# Altium schematic sheet Sheet2.SchDoc
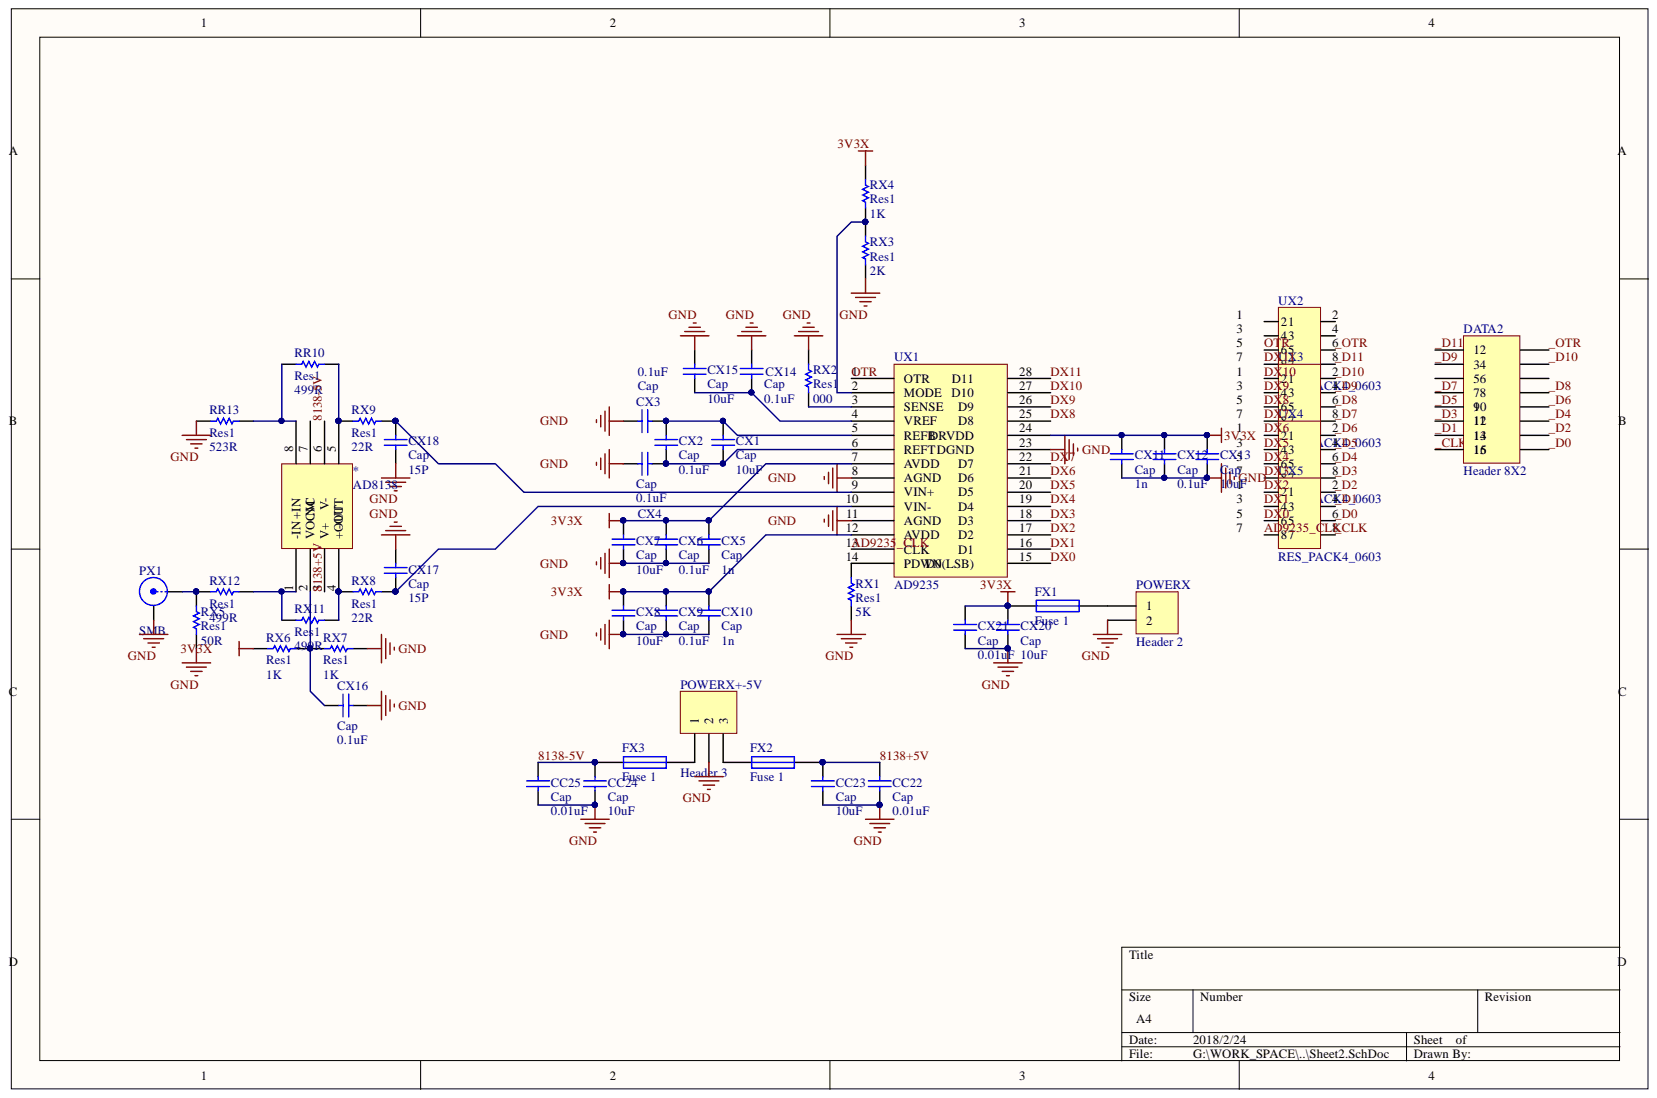
[diagram p0: Sheet2.SchDoc]

## Parts
*  AD8138  [AD8138]
CC22  Cap  value 0.01uF  [6-0805_N]
CC23  Cap  value 10uF  [C1206]
CC24  Cap  value 10uF  [C1206]
CC25  Cap  value 0.01uF  [6-0805_N]
CX1  Cap  value 10uF  [C1206]
CX2  Cap  value 0.1uF  [6-0805_N]
CX3  Cap  value 0.1uF  [6-0805_N]
CX4  Cap  value 0.1uF  [6-0805_N]
CX5  Cap  value 1n  [6-0805_N]
CX6  Cap  value 0.1uF  [6-0805_N]
CX7  Cap  value 10uF  [C1206]
CX8  Cap  value 10uF  [C1206]
CX9  Cap  value 0.1uF  [6-0805_N]
CX10  Cap  value 1n  [6-0805_N]
CX11  Cap  value 1n  [6-0805_N]
CX12  Cap  value 0.1uF  [6-0805_N]
CX13  Cap  value 10uF  [C1206]
CX14  Cap  value 0.1uF  [6-0805_N]
CX15  Cap  value 10uF  [C1206]
CX16  Cap  value 0.1uF  [6-0805_N]
CX17  Cap  value 15P  [6-0805_N]
CX18  Cap  value 15P  [6-0805_N]
CX20  Cap  value 10uF  [C1206]
CX21  Cap  value 0.01uF  [6-0805_N]
DATA2  Header 8X2  [HDR2X8]
FX1  Fuse 1  [6-0805_N]
FX2  Fuse 1  [6-0805_N]
FX3  Fuse 1  [6-0805_N]
POWERX  Header 2  [HDR1X2]
POWERX+-5V  Header 3  [HDR1X3]
PX1  SMB  [SMB_V-RJ45]
RR10  Res1  value 499R  [6-0805_N]
RR13  Res1  value 523R  [6-0805_N]
RX1  Res1  value 5K  [6-0805_N]
RX2  Res1  value 000  [6-0805_N]
RX3  Res1  value 2K  [6-0805_N]
RX4  Res1  value 1K  [6-0805_N]
RX5  Res1  value 50R  [6-0805_N]
RX6  Res1  value 1K  [6-0805_N]
RX7  Res1  value 1K  [6-0805_N]
RX8  Res1  value 22R  [6-0805_N]
RX9  Res1  value 22R  [6-0805_N]
RX11  Res1  value 499R  [6-0805_N]
RX12  Res1  value 499R  [6-0805_N]
UX1  AD9235  [AD9235]
UX2  RES_PACK4_0603  [8P4R_0603]
UX3  RES_PACK4_0603  [8P4R_0603]
UX4  RES_PACK4_0603  [8P4R_0603]
UX5  RES_PACK4_0603  [8P4R_0603]

## Nets
3V3X: CX5.2 CX6.2 CX7.2 CX8.2 CX9.2 CX10.2 CX11.1 CX12.1 CX13.1 CX20.2 CX21.2 FX1.1 RX4.2 RX6.2 UX1.7(AVDD) UX1.12(AVDD) UX1.24
GND: CC22.1 CC23.1 CC24.1 CC25.1 CX3.2 CX4.1 CX5.1 CX6.1 CX7.1 CX8.1 CX9.1 CX10.1 CX11.2 CX12.2 CX13.2 CX14.2 CX15.2 CX16.1 CX17.1 CX18.1 CX20.1 CX21.1 POWERX.2 POWERX+-5V.2 PX1.2 RR13.2 RX1.1 RX2.2 RX3.1 RX5.1 RX7.1 UX1.8(AGND) UX1.11(AGND) UX1.23
8138+5V: *.3(V+) CC22.2 CC23.2 FX2.2
8138-5V: *.6 CC24.2 CC25.2 FX3.1
_CLK: DATA2.15 UX5.8
_D0: DATA2.16 UX5.6
_D1: DATA2.13 UX5.4
_D2: DATA2.14 UX5.2
_D3: DATA2.11 UX4.8
_D4: DATA2.12 UX4.6
_D5: DATA2.9 UX4.4
_D6: DATA2.10 UX4.2
_D7: DATA2.7 UX3.8
_D8: DATA2.8 UX3.6
_D9: DATA2.3 UX3.4
_D10: DATA2.4 UX3.2
_D11: DATA2.1 UX2.8
_OTR: DATA2.2 UX2.6
AD9235_CLK: UX1.13 UX5.7(8_7)
DX0: UX1.15 UX5.5(6_5)
DX1: UX1.16 UX5.3(4_3)
DX2: UX1.17 UX5.1(2_1)
DX3: UX1.18 UX4.7(8_7)
DX4: UX1.19 UX4.5(6_5)
DX5: UX1.20 UX4.3(4_3)
DX6: UX1.21 UX4.1(2_1)
DX7: UX1.22 UX3.7(8_7)
DX8: UX1.25 UX3.5(6_5)
DX9: UX1.26 UX3.3(4_3)
DX10: UX1.27 UX3.1(2_1)
DX11: UX1.28 UX2.7(8_7)
OTR: UX1.1(OTR) UX2.5(6_5)
Net*_1: *.1(-IN_+IN) RX11.2 RX12.1
Net*_2: *.2(VOCM) CX16.2 RX6.1 RX7.2
Net*_4: *.4(+OUT) RX8.2 RX11.1
Net*_5: *.5 RR10.1 RX9.2
Net*_8: *.8 RR10.2 RR13.1
NetCX1_1: CX1.1 CX2.1 CX4.2 UX1.6
NetCX1_2: CX1.2 CX2.2 CX3.1 UX1.5(REFB)
NetCX14_1: CX14.1 CX15.1 UX1.4(VREF)
NetCX17_2: CX17.2 RX8.1 UX1.10(VIN-)
NetCX18_2: CX18.2 RX9.1 UX1.9(VIN+)
NetFX1_2: FX1.2 POWERX.1
NetFX2_1: FX2.1 POWERX+-5V.3
NetFX3_2: FX3.2 POWERX+-5V.1
NetPX1_1: PX1.1 RX5.2 RX12.2
NetRX1_2: RX1.2 UX1.14(PDWN)
NetRX2_1: RX2.1 UX1.3(SENSE)
NetRX3_2: RX3.2 RX4.1 UX1.2(MODE)

Not connected: * 7; DATA2 5 6; UX2 1(2_1) 2 3(4_3) 4

## Library data of the parts
CC22-CC25 CX1-CX18 CX20 CX21 = Cap: Capacitor; Component Kind: Standard; LatestRevisionDate: 17-Jul-2002; LatestRevisionNote: Re-released for DXP Platform.; Library Name: Miscellaneous Devices.IntLib; PackageDescription: Radial Cap, Thru-Hole; 2 Leads; 0.3 in Pin Spacing; PackageReference: RAD-0.3; Published: 8-Jun-2000; Signal Integrity: Cap; Simulation: CAP; Publisher: Altium Limited
DATA2 = Header 8X2: Header, 8-Pin, Dual row; Component Kind: Standard; LatestRevisionDate: 17-Jul-2002; LatestRevisionNote: Re-released for DXP Platform.; Library Name: Miscellaneous Connectors.IntLib; Signal Integrity: Connector; Publisher: Altium Limited
FX1-FX3 = Fuse 1: Fuse; Component Kind: Standard; LatestRevisionDate: 17-Jul-2002; LatestRevisionNote: Re-released for DXP Platform.; Library Name: Miscellaneous Devices.IntLib; PackageDescription: Thru-Hole; 2 Leads; PackageReference: PIN-W2/E2.8; Published: 8-Jun-2000; Simulation: FUSE; Publisher: Altium Limited
POWERX = Header 2: Header, 2-Pin; Component Kind: Standard; LatestRevisionDate: 17-Jul-2002; LatestRevisionNote: Re-released for DXP Platform.; Library Name: Miscellaneous Connectors.IntLib; Signal Integrity: Connector; Publisher: Altium Limited
POWERX+-5V = Header 3: Header, 3-Pin; Component Kind: Standard; LatestRevisionDate: 17-Jul-2002; LatestRevisionNote: Re-released for DXP Platform.; Library Name: Miscellaneous Connectors.IntLib; Signal Integrity: Connector; Publisher: Altium Limited
PX1 = SMB: SMB Straight Connector; Component Kind: Standard; LatestRevisionDate: 17-Jul-2002; LatestRevisionNote: Re-released for DXP Platform.; Library Name: Miscellaneous Connectors.IntLib; Signal Integrity: Connector; Publisher: Altium Limited
RR10 RR13 RX1-RX6 = Res1: Resistor; Component Kind: Standard; LatestRevisionDate: 17-Jul-2002; LatestRevisionNote: Re-released for DXP Platform.; Library Name: Miscellaneous Devices.IntLib; PackageDescription: Axial Device, Thru-Hole; 2 Leads; 0.3 in Pin Spacing; PackageReference: AXIAL-0.3; Published: 8-Jun-2000; Signal Integrity: Res; Simulation: RESISTOR; Publisher: Altium Limited
RX7 = Res1: Resistor; Value: 1K; Component Kind: Standard; LatestRevisionDate: 17-Jul-2002; LatestRevisionNote: Re-released for DXP Platform.; Library Name: Miscellaneous Devices.IntLib; PackageDescription: Axial Device, Thru-Hole; 2 Leads; 0.3 in Pin Spacing; PackageReference: AXIAL-0.3; Published: 8-Jun-2000; Signal Integrity: Res; Simulation: RESISTOR; Publisher: Altium Limited
RX8 RX9 = Res1: Resistor; Value: 22R; Component Kind: Standard; LatestRevisionDate: 17-Jul-2002; LatestRevisionNote: Re-released for DXP Platform.; Library Name: Miscellaneous Devices.IntLib; PackageDescription: Axial Device, Thru-Hole; 2 Leads; 0.3 in Pin Spacing; PackageReference: AXIAL-0.3; Published: 8-Jun-2000; Signal Integrity: Res; Simulation: RESISTOR; Publisher: Altium Limited
RX11 RX12 = Res1: Resistor; Value: 499R; Component Kind: Standard; LatestRevisionDate: 17-Jul-2002; LatestRevisionNote: Re-released for DXP Platform.; Library Name: Miscellaneous Devices.IntLib; PackageDescription: Axial Device, Thru-Hole; 2 Leads; 0.3 in Pin Spacing; PackageReference: AXIAL-0.3; Published: 8-Jun-2000; Signal Integrity: Res; Simulation: RESISTOR; Publisher: Altium Limited
* = AD8138: Component Kind: Standard; Library Name: AD8138.SchLib
UX1 = AD9235: Component Kind: Standard; Library Name: AD9235.SchLib
UX2-UX5 = RES_PACK4_0603: Component Kind: Standard; Library Name: RES_PACK4_0603.SchLib
